# Altium schematic sheet Sheet1.SchDoc(Sheet1)
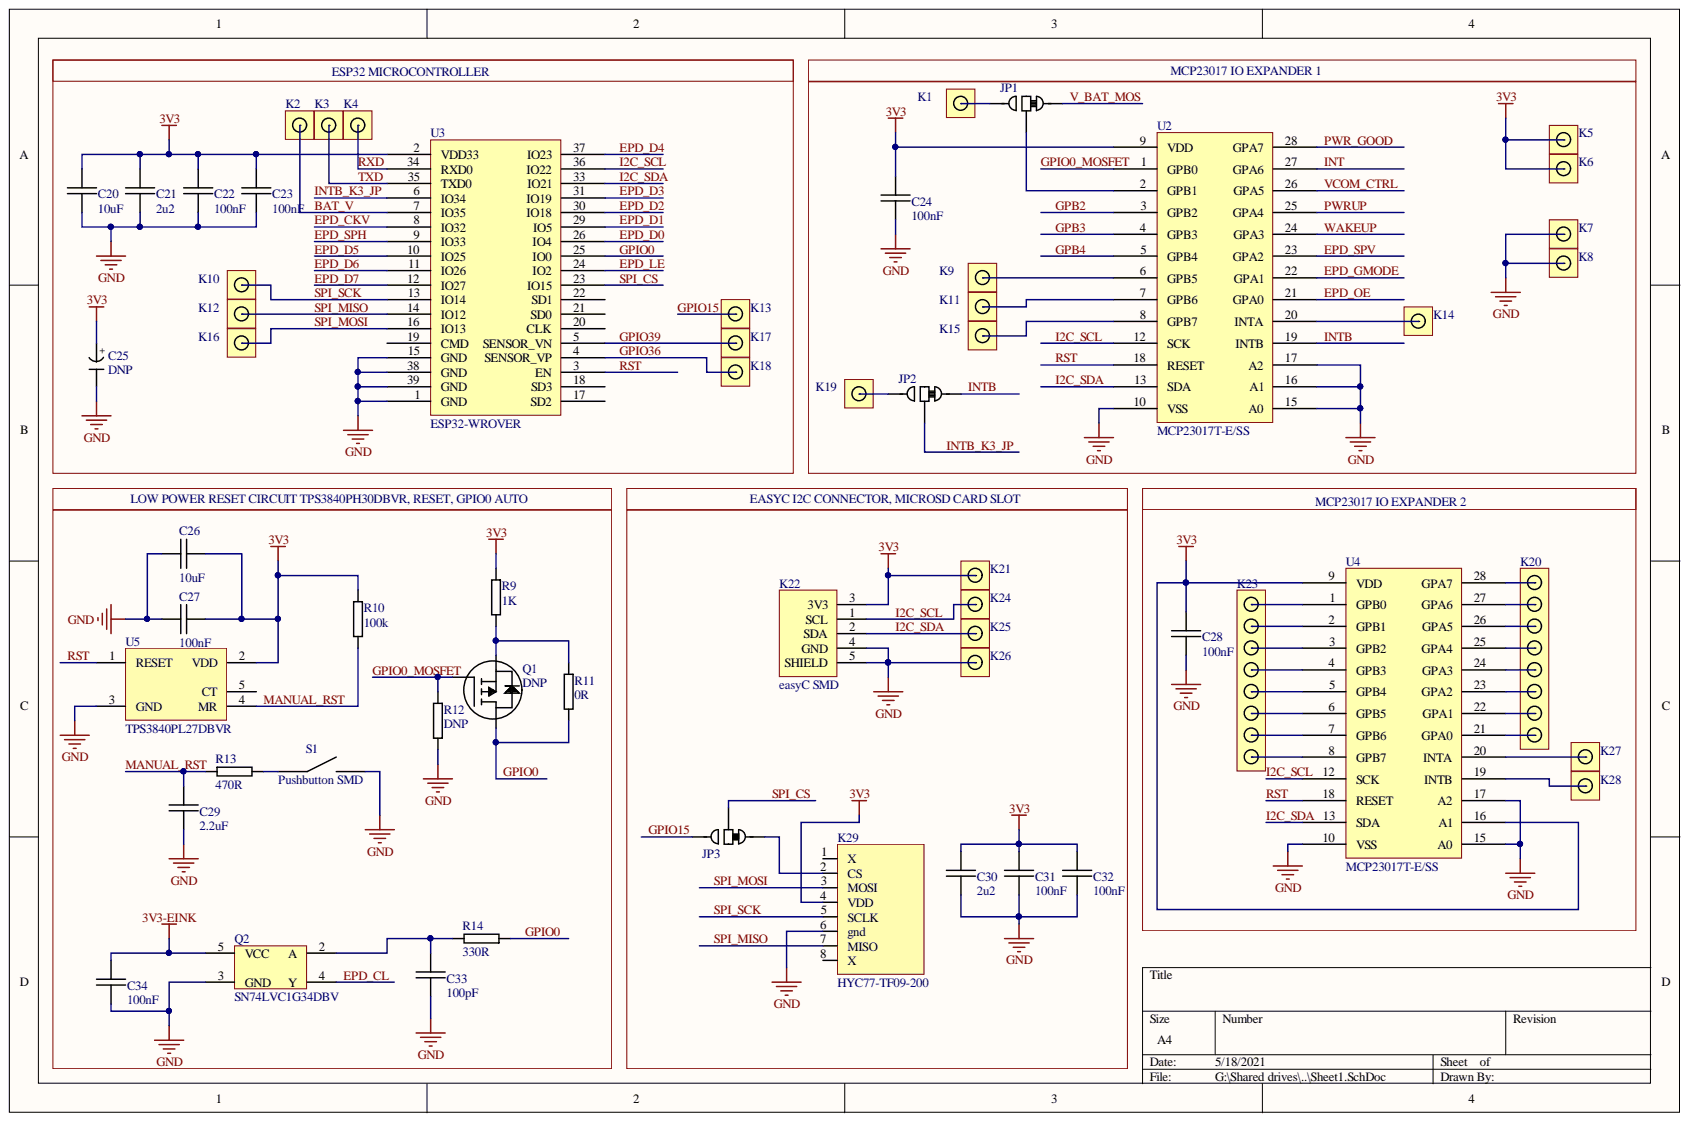
[diagram p0: Sheet1.SchDoc]

## Parts
C20  10uF  [1206C]
C21  2u2  [0603C]
C22  100nF  [0603C]
C23  100nF  [0603C]
C24  100nF  [0603C]
C25  DNP  [2917C]
C26  10uF  [1206C]
C27  100nF  [0603C]
C28  100nF  [0603C]
C29  2.2uF  [0603C]
C30  2u2  [0603C]
C31  100nF  [0603C]
C32  100nF  [0603C]
C33  100pF  [0603C]
C34  100nF  [0603C]
JP1  2/3 pads connected  [SMD_JUMPER 2/3 pads]  (value not drawn)
JP2  2/3 pads connected  [SMD_JUMPER 2/3 pads]  (value not drawn)
JP3  left connection  [SMD_JUMPER 2/3 pads left connection]  (value not drawn)
K1  GPB1  [HEADER MALE 1x1 - NO_SILK]  (value not drawn)
K2  HEADER 1x1  [HEADER MALE 1x1 - NO_SILK]  (value not drawn)
K3  HEADER 1x1  [HEADER MALE 1x1 - NO_SILK]  (value not drawn)
K4  HEADER 1x1  [HEADER MALE 1x1 - NO_SILK]  (value not drawn)
K5  HEADER 1x1  [HEADER MALE 1x1 - NO_SILK]  (value not drawn)
K6  HEADER 1x1  [HEADER MALE 1x1 - NO_SILK]  (value not drawn)
K7  HEADER 1x1  [HEADER MALE 1x1 - NO_SILK]  (value not drawn)
K8  HEADER 1x1  [HEADER MALE 1x1 - NO_SILK]  (value not drawn)
K9  GPB5  [HEADER MALE 1x1 - NO_SILK]  (value not drawn)
K10  HEADER 1x1  [HEADER MALE 1x1 - NO_SILK]  (value not drawn)
K11  GPB5  [HEADER MALE 1x1 - NO_SILK]  (value not drawn)
K12  HEADER 1x1  [HEADER MALE 1x1 - NO_SILK]  (value not drawn)
K13  HEADER 1x1  [HEADER MALE 1x1 - NO_SILK]  (value not drawn)
K14  HEADER 1x1  [HEADER MALE 1x1 - NO_SILK]  (value not drawn)
K15  GPB5  [HEADER MALE 1x1 - NO_SILK]  (value not drawn)
K16  HEADER 1x1  [HEADER MALE 1x1 - NO_SILK]  (value not drawn)
K17  HEADER 1x1  [HEADER MALE 1x1 - NO_SILK]  (value not drawn)
K18  HEADER 1x1  [HEADER MALE 1x1 - NO_SILK]  (value not drawn)
K19  HEADER 1x1  [HEADER MALE 1x1 - NO_SILK]  (value not drawn)
K20  HEADER 8x1  [HEADER MALE 8x1 - NO_SILK]  (value not drawn)
K21  3V3  [HEADER MALE 1x1 - NO_SILK]  (value not drawn)
K22  easyC SMD  [EasyC connector]
K23  HEADER 8x1  [HEADER MALE 8x1 - NO_SILK]  (value not drawn)
K24  SCL  [HEADER MALE 1x1 - NO_SILK]  (value not drawn)
K25  SDA  [HEADER MALE 1x1 - NO_SILK]  (value not drawn)
K26  GND  [HEADER MALE 1x1 - NO_SILK]  (value not drawn)
K27  HEADER 1x1  [HEADER MALE 1x1 - NO_SILK]  (value not drawn)
K28  HEADER 1x1  [HEADER MALE 1x1 - NO_SILK]  (value not drawn)
K29  HYC77-TF09-200  [HYC77-TF09-200]
Q1  DNP  [SOT-23-3]
Q2  SN74LVC1G34DBV  [SOT-23-5]
R9  1K  [0603R]
R10  100k  [0603R]
R11  0R  [0603R]
R12  DNP  [0603R]
R13  470R  [0603R]
R14  330R  [0603R]
S1  Pushbutton SMD  [Pushbutton SMD]
U2  MCP23017T-E/SS  [SSOP-28 10.20x5.30mm]
U3  ESP32-WROVER  [ESP32-WROVER]
U4  MCP23017T-E/SS  [SSOP-28 10.20x5.30mm]
U5  TPS3840PL27DBVR  [TPS3840PH30DBVR]

## Nets
3V3: C20.2 C21.2 C22.2 C23.2 C24.2 C25.1 C26.2 C27.2 C28.2 C30.2 C31.2 C32.2 K5.1 K6.1 K21.1 K22.3(3V3) K29.4(VDD) R9.2 R10.2 U2.9(VDD) U3.2(VDD33) U4.9(VDD) U4.16(A1) U5.2(VDD)
3V3-EINK: C34.2 Q2.5(VCC)
GND: C20.1 C21.1 C22.1 C23.1 C24.1 C25.2 C26.1 C27.1 C28.1 C29.1 C30.1 C31.1 C32.1 C33.1 C34.1 K7.1 K8.1 K22.4(GND) K22.5(SHIELD) K26.1 K29.6(gnd) Q2.3(GND) R12.1 S1.2 U2.10(VSS) U2.15(A0) U2.16(A1) U2.17(A2) U3.1(GND) U3.15(GND) U3.38(GND) U3.39(GND) U4.10(VSS) U4.15(A0) U4.17(A2) U5.3(GND)
BAT_V: K2.1 U3.7(IO35)
EPD_CKV: U3.8(IO32)
EPD_CL: Q2.4(Y)
EPD_D0: U3.26(IO4)
EPD_D1: U3.29(IO5)
EPD_D2: U3.30(IO18)
EPD_D3: U3.31(IO19)
EPD_D4: U3.37(IO23)
EPD_D5: U3.10(IO25)
EPD_D6: U3.11(IO26)
EPD_D7: U3.12(IO27)
EPD_GMODE: U2.22(GPA1)
EPD_LE: U3.24(IO2)
EPD_OE: U2.21(GPA0)
EPD_SPH: U3.9(IO33)
EPD_SPV: U2.23(GPA2)
GPB2: U2.3(GPB2)
GPB3: U2.4(GPB3)
GPB4: U2.5(GPB4)
GPIO0: Q1.3 R11.1 R14.2 U3.25(IO0)
GPIO0_MOSFET: Q1.1 R12.2 U2.1(GPB0)
GPIO15: JP3.3 K13.1
GPIO36: K18.1 U3.4(SENSOR_VP)
GPIO39: K17.1 U3.5(SENSOR_VN)
I2C_SCL: K22.1(SCL) K24.1 U2.12(SCK) U3.36(IO22) U4.12(SCK)
I2C_SDA: K22.2(SDA) K25.1 U2.13(SDA) U3.33(IO21) U4.13(SDA)
INT: U2.27(GPA6)
INTB: JP2.3 U2.19(INTB)
INTB_K3_JP: JP2.2 U3.6(IO34)
MANUAL_RST: C29.2 R10.1 R13.2 U5.4(MR)
PWR_GOOD: U2.28(GPA7)
PWRUP: U2.25(GPA4)
RST: U2.18(RESET) U3.3(EN) U4.18(RESET) U5.1(RESET)
RXD: K4.1 U3.34(RXD0)
SPI_CS: JP3.2 U3.23(IO15)
SPI_MISO: K12.1 K29.7(MISO) U3.14(IO12)
SPI_MOSI: K16.1 K29.3(MOSI) U3.16(IO13)
SPI_SCK: K10.1 K29.5(SCLK) U3.13(IO14)
TXD: K3.1 U3.35(TXD0)
V_BAT_MOS: JP1.3
VCOM_CTRL: U2.26(GPA5)
WAKEUP: U2.24(GPA3)
NetC33_2: C33.2 Q2.2(A) R14.1
NetJP1_1: JP1.1 K1.1
NetJP1_2: JP1.2 U2.2(GPB1)
NetJP2_1: JP2.1 K19.1
NetJP3_1: JP3.1 K29.2(CS)
NetK9_1: K9.1 U2.6(GPB5)
NetK11_1: K11.1 U2.7(GPB6)
NetK14_1: K14.1 U2.20(INTA)
NetK15_1: K15.1 U2.8(GPB7)
NetK20_1: K20.1 U4.28(GPA7)
NetK20_2: K20.2 U4.27(GPA6)
NetK20_3: K20.3 U4.26(GPA5)
NetK20_4: K20.4 U4.25(GPA4)
NetK20_5: K20.5 U4.24(GPA3)
NetK20_6: K20.6 U4.23(GPA2)
NetK20_7: K20.7 U4.22(GPA1)
NetK20_8: K20.8 U4.21(GPA0)
NetK23_1: K23.1 U4.1(GPB0)
NetK23_2: K23.2 U4.2(GPB1)
NetK23_3: K23.3 U4.3(GPB2)
NetK23_4: K23.4 U4.4(GPB3)
NetK23_5: K23.5 U4.5(GPB4)
NetK23_6: K23.6 U4.6(GPB5)
NetK23_7: K23.7 U4.7(GPB6)
NetK23_8: K23.8 U4.8(GPB7)
NetK27_1: K27.1 U4.20(INTA)
NetK28_1: K28.1 U4.19(INTB)
NetQ1_2: Q1.2 R9.1 R11.2
NetR13_1: R13.1 S1.1

Not connected: K29 1(X) 8(X); U3 17(SD2) 18(SD3) 19(CMD) 20(CLK) 21(SD0) 22(SD1); U5 5(CT)

## Library data of the parts
C20 C26 = 1206C: Component Kind: Standard; Library Name: e-radionica.com schematics.SchLib
C21-C24 C27-C34 = 0603C: Component Kind: Standard; Library Name: e-radionica.com schematics.SchLib
C25 = 2917C: Component Kind: Standard; Library Name: e-radionica.com schematics.SchLib
JP1 JP2 = SMD_JUMPER 2/3 pads connected: Component Kind: Standard; Library Name: e-radionica.com schematics.SchLib
JP3 = SMD_JUMPER 2/3 Left pad connected: Component Kind: Standard; Library Name: e-radionica.com schematics.SchLib
K1 K9 K11 K15 K21 K24-K26 = HEADER 1x1: Component Kind: Standard; Library Name: e-radionica.com schematics.SchLib
K29 = HYC77-TF09-200: MicroSD Inkplate; Component Kind: Standard; Library Name: e-radionica.com schematics.SchLib
Q1 = PMOS SOT-23-3: Supplier Part Number 3: DMP3120L-7; Supplier Part Number 2: 621-DMP3120L-7; Supplier Part Number 1: DMP3120L-7DI-ND; Supplier 3: Arrow; Supplier 2: Mouser; Supplier 1: Digi-Key; Component Kind: Standard; Library Name: e-radionica.com schematics.SchLib
Q2 = SN74LVC1G34DBV: Inkplate; Component Kind: Standard; Library Name: e-radionica.com schematics.SchLib
R9-R14 = 0603R: Component Kind: Standard; Library Name: e-radionica.com schematics.SchLib
U2 U4 = MCP23017_SS: Component Kind: Standard; Library Name: e-radionica.com schematics.SchLib
U5 = TPS3840PH30DBVR: Component Kind: Standard; Library Name: e-radionica.com schematics.SchLib
K2-K8 K10 K12-K14 K16-K19 K27 K28 = HEADER 1x1: Component Kind: Standard; Library Name: e-radionica.com schematics.SchLib
K20 K23 = HEADER 8x1: Component Kind: Standard; Library Name: e-radionica.com schematics.SchLib
K22 = easyC SMD: Component Kind: Standard; Library Name: e-radionica.com schematics.SchLib
S1 = Pushbutton SMD: Component Kind: Standard; Library Name: e-radionica.com schematics.SchLib
U3 = ESP32-WROVER: Component Kind: Standard; Library Name: e-radionica.com schematics.SchLib
